# Altium schematic sheet lv_power_12V_cv.SchDoc(lv_power_12V_cv)
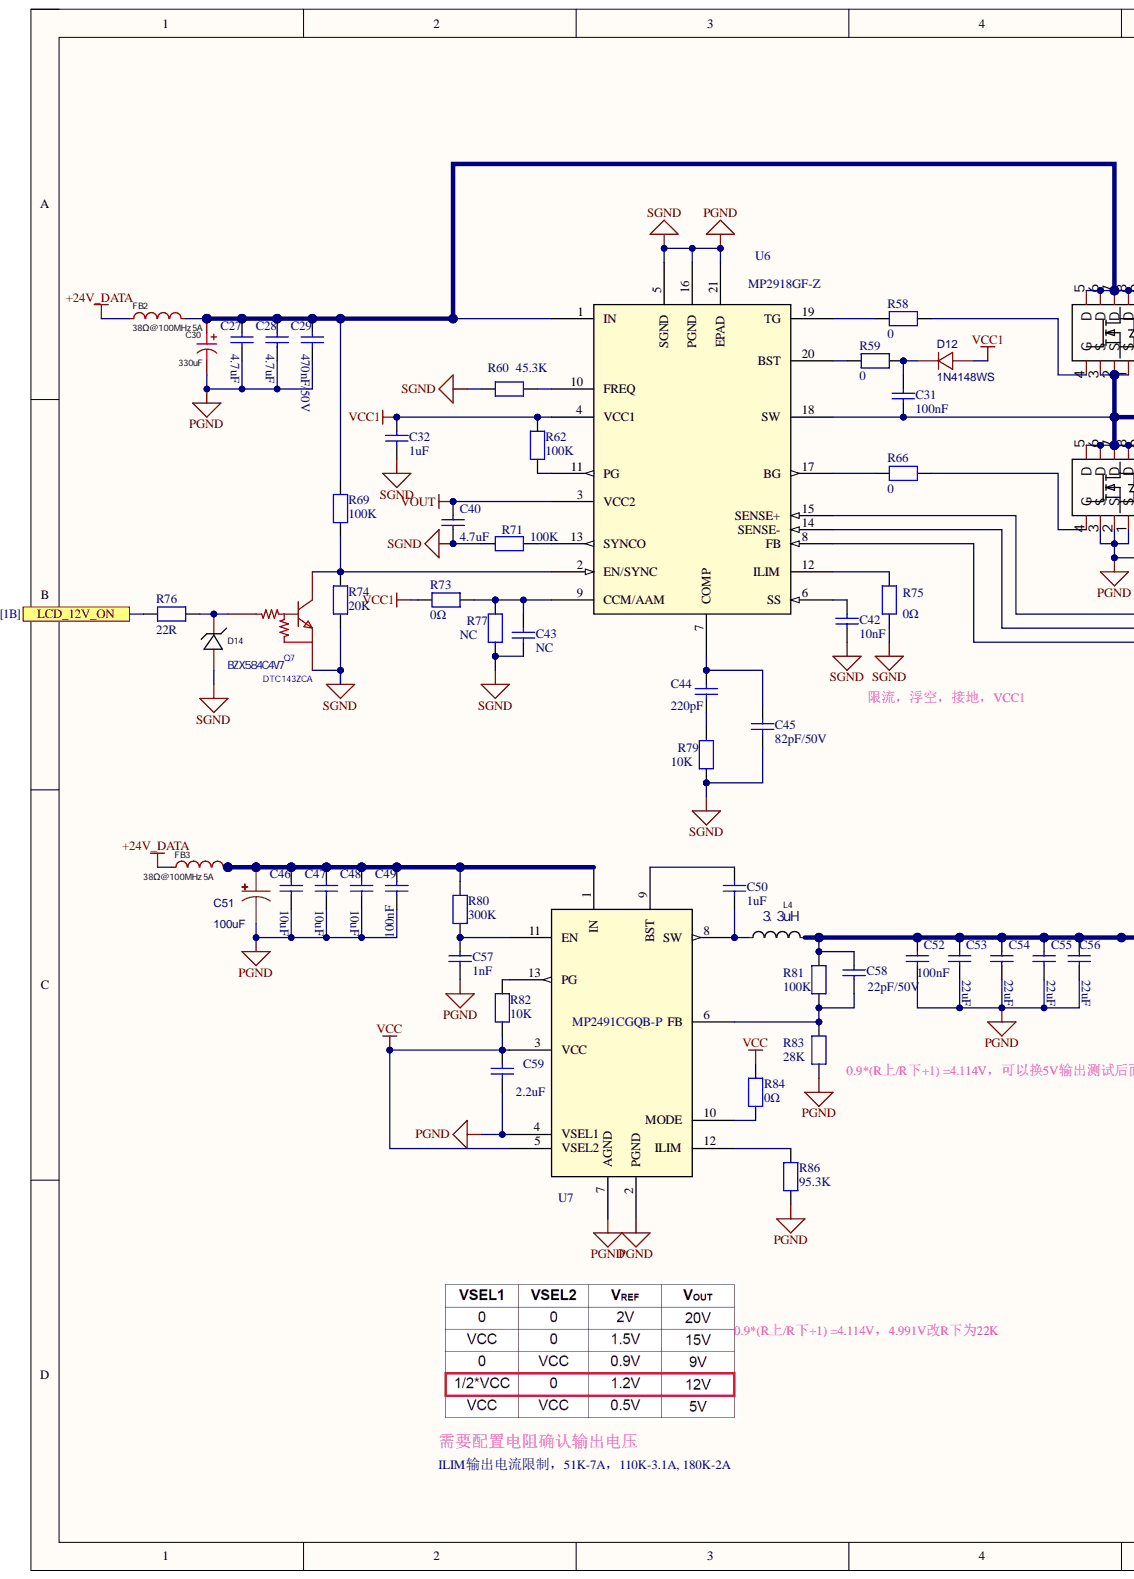
[diagram p0: lv_power_12V_cv.SchDoc, left]
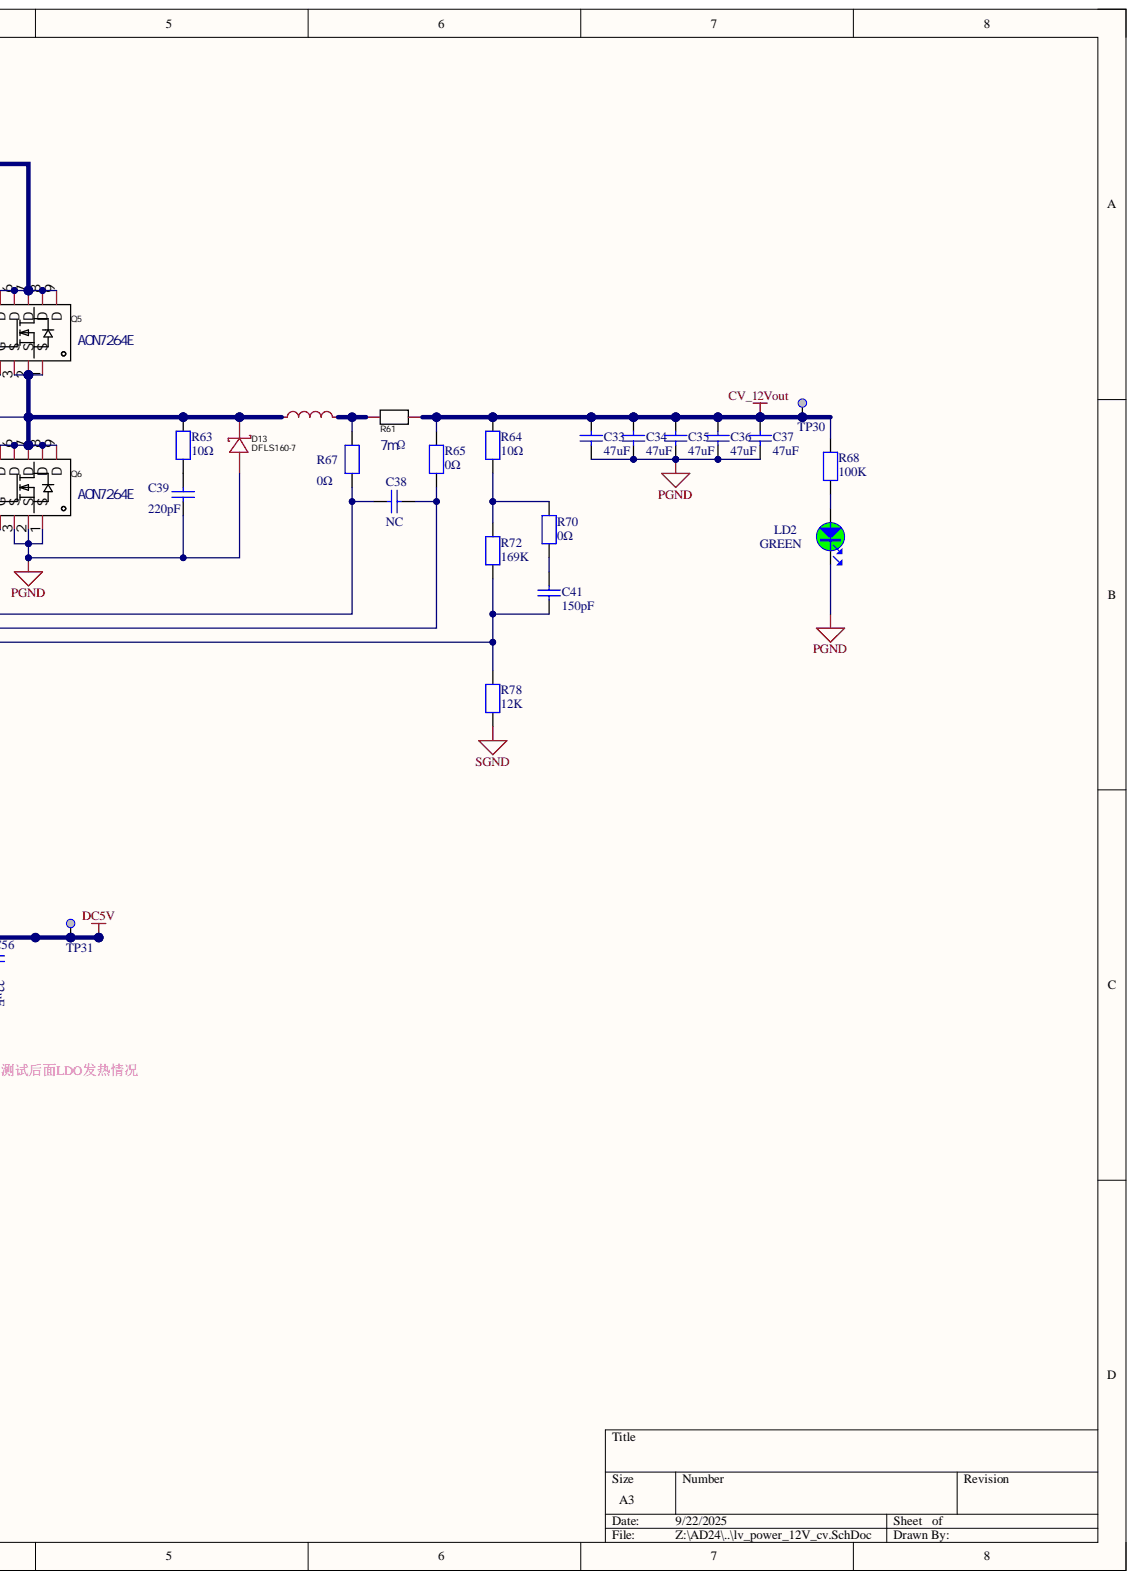
[diagram p1: lv_power_12V_cv.SchDoc, right]

## Parts
C27  4.7uF  [C1206]
C28  4.7uF  [C1206]
C29  470nF/50V  [C0603]
C30  330uF  [CAP-SMD_BD10.0-L10.3-W10.3-LS10.9-FD]
C31  100nF  [C0402]
C32  1uF  [C0402]
C33  47uF  [1206]
C34  47uF  [1206]
C35  47uF  [1206]
C36  47uF  [1206]
C37  47uF  [1206]
C38  NC  [C0402]
C39  220pF  [C0402]
C40  4.7uF  [C0603]
C41  150pF  [C0402]
C42  10nF  [C0402]
C43  NC  [C0402]
C44  220pF  [C0402]
C45  82pF/50V  [C0402]
C46  10uF  [C1206]
C47  10uF  [C1206]
C48  10uF  [C1206]
C49  100nF  [C0402]
C50  1uF  [C0805]
C51  100uF  [CAP-SMD_BD6.3-L6.6-W6.6-LS7.6-FD]
C52  100nF  [C0402]
C53  22uF  [C0805]
C54  22uF  [C0805]
C55  22uF  [C0805]
C56  22uF  [C0805]
C57  1nF  [C0402]
C58  22pF/50V  [C0402]
C59  2.2uF  [C0603]
D12  1N4148WS  [SOD-123_L2.8-W1.8-LS3.7-RD]
D13  DFLS160-7  [POWERDI-123_L2.8-W1.8-LS3.7-RD]
D14  BZX584C4V7  [SOD-523F_L1.2-W0.8-LS1.7-RD]
FB2  PZ3216D380-5R0TF  [L1206]  (value not drawn)
FB3  LCSC Part Name: 38Ω@100MHz 5A  [L1206]  (hidden comment: PZ3216D380-5R0TF)
L3  15uH  [IND-SMD_L11.9-W11.0]  (value not drawn)
L4  3.3uH  [IND-SMD_L7.2-W6.6_GPSR07X0]
LD2  value GREEN  [LED_0603_GREEN]  (hidden comment: LED_green)
Q5  AON7264E  [DFN-8_L3.0-W3.0-P0.65-BL_VBQFXXXX]
Q6  AON7264E  [DFN-8_L3.0-W3.0-P0.65-BL_VBQFXXXX]
Q7  DTC143ZCA  [SOT-416_L1.6-W0.8-P1.00-LS1.6-BR]
R58  0  [R0603]
R59  0  [R0603]
R60  45.3K  [R0402]
R61  7mΩ  [R2512]
R62  100K  [R0402]
R63  10Ω  [R0603]
R64  10Ω  [R0603]
R65  0Ω  [R0402]
R66  0  [R0603]
R67  0Ω  [R0402]
R68  100K  [R0603]
R69  100K  [R0603]
R70  0Ω  [R0603]
R71  100K  [R0402]
R72  169K  [R0603]
R73  0Ω  [R0402]
R74  20K  [R0603]
R75  0Ω  [R0402]
R76  22R  [R0402]
R77  NC  [R0402]
R78  12K  [R0603]
R79  10K  [R0402]
R80  300K  [R0603]
R81  100K  [R0402]
R82  10K  [R0402]
R83  28K  [R0402]
R84  0Ω  [R0402]
R86  95.3K  [R0402]
TP30  Test Point  [TP_SMD_1MM]  (value not drawn)  (no BOM)
TP31  Test Point  [TP_SMD_1MM]  (value not drawn)  (no BOM)
U6  MP2918GF-Z  [TSSOP-20EP_MP2918_MNP]
U7  MP2491CGQB-P  [QFN-13_2p5MMx3MM_MNP]

## Ports
LCD_12V_ON

## Nets
+24V_DATA: FB2.1 FB3.1
CV_12Vout: C33.2 C34.2 C35.2 C36.2 C37.2 R61.2 R64.2 R65.2 R68.2 TP30.1
DC5V: C52.1 C53.2 C54.2 C55.2 C56.2 C58.2 L4.2 R81.1 TP31.1
PGND: C27.1 C28.1 C29.1 C30.2 C32.2 C33.1 C34.1 C35.1 C36.1 C37.1 C39.2 C40.1 C42.2 C43.2 C45.2 C46.1 C47.1 C48.1 C49.2 C51.2 C52.2 C53.1 C54.1 C55.1 C56.1 C57.1 C59.1 D13.2 D14.2 LD2.2 Q6.1(S) Q6.2(S) Q6.3(S) Q7.2 R60.1 R71.2 R74.2 R75.2 R77.2 R78.1 R79.2 R83.2 R86.2 U6.5(SGND) U6.16(PGND) U6.21(EPAD) U7.2(PGND) U7.4(VSEL1) U7.7(AGND)
VCC: C59.2 R82.1 R84.2 U7.3(VCC) U7.5(VSEL2)
VCC1: C32.1 D12.2 R62.2 R73.1 U6.4(VCC1)
VOUT: C40.2 U6.3(VCC2)
LCD_12V_ON: R76.1 ; port LCD_12V_ON
NetC27_2: C27.2 C28.2 C29.2 C30.1 FB2.2 Q5.5(D) Q5.6(D) Q5.7(D) Q5.8(D) Q5.9(D) R69.1 U6.1(IN)
NetC31_1: C31.1 D12.1 R59.2
NetC31_2: C31.2 D13.1 L3.1 Q5.1(S) Q5.2(S) Q5.3(S) Q6.5(D) Q6.6(D) Q6.7(D) Q6.8(D) Q6.9(D) R63.2 U6.18(SW)
NetC38_1: C38.1 R67.1 U6.15(SENSE+)
NetC38_2: C38.2 R65.1 U6.14(SENSE-)
NetC39_1: C39.1 R63.1
NetC41_1: C41.1 R72.1 R78.2 U6.8(FB)
NetC41_2: C41.2 R70.1
NetC42_1: C42.1 U6.6(SS)
NetC43_1: C43.1 R73.2 R77.1 U6.9(CCM/AAM)
NetC44_1: C44.1 C45.1 U6.7(COMP)
NetC44_2: C44.2 R79.1
NetC46_2: C46.2 C47.2 C48.2 C49.1 C51.1 FB3.2 R80.2 U7.1(IN)
NetC50_1: C50.1 U7.9(BST)
NetC50_2: C50.2 L4.1 U7.8(SW)
NetC57_2: C57.2 R80.1 U7.11(EN)
NetC58_1: C58.1 R81.2 R83.1 U7.6(FB)
NetD14_1: D14.1 Q7.1 R76.2
NetL3_2: L3.2 R61.1 R67.2
NetLD2_1: LD2.1 R68.1
NetQ5_4: Q5.4(G) R58.2
NetQ6_4: Q6.4(G) R66.2
NetQ7_3: Q7.3 R69.2 R74.1 U6.2(EN/SYNC)
NetR58_1: R58.1 U6.19(TG)
NetR59_1: R59.1 U6.20(BST)
NetR60_2: R60.2 U6.10(FREQ)
NetR62_1: R62.1 U6.11(PG)
NetR64_1: R64.1 R70.2 R72.2
NetR66_1: R66.1 U6.17(BG)
NetR71_1: R71.1 U6.13(SYNCO)
NetR75_1: R75.1 U6.12(ILIM)
NetR82_2: R82.2 U7.13(PG)
NetR84_1: R84.1 U7.10(MODE)
NetR86_1: R86.1 U7.12(ILIM)

## Library data of the parts
C27 C28 = Capacitor_2: 50V, X7R, 10%; Internal_Part_Number: MCD-00014; Manufacturer: muRata; Manufacturer_Part_Number: GRM31CR71H475KA12L; Component Kind: Standard; ComponentLink1Description: Datasheet; ComponentLink1URL: http://tunmcdsvn.tun.st.com/Component_Datasheet_Library/Trunk/Capacitors/Yageo_Multilayer_capacitor_X5R_series_100pF_to_100uF; Library Name: HiPin_Library_AD.IntLib; Value: 4.7uF
C29 = Capacitor_2: 50V, X7R, 10%; Manufacturer: YAGEO; Manufacturer_Part_Number: CC0603KRX7R9BB474; Component Kind: Standard; ComponentLink1Description: Datasheet; ComponentLink1URL: http://tunmcdsvn.tun.st.com/Component_Datasheet_Library/Trunk/Capacitors/Yageo_Multilayer_capacitor_X5R_series_100pF_to_100uF; Library Name: HiPin_Library_AD.IntLib
C30 = HV1V337M1010PZ: 330uF, 35V, 20%; Manufacturer: HONOR(荣誉); Add into BOM: yes; L: 10mm; Component Kind: Standard; Convert to PCB: yes; Datasheet: https://atta.szlcsc.com/upload/public/pdf/source/20240802/61683F5000C7CFED5606ABBE3CFBE0F5.pdf; Device: HV1V337M1010PZ; JLCPCB Part Class: Extended Part; LCSC Part Name: 330uF ±20% 35V; Library Name: HiPin_Library_AD.IntLib; Manufacturer Part: HV1V337M1010PZ; Operating Temperature: -55℃~+105℃; Rated Voltage: 35V; Supplier: LCSC; Supplier Footprint: SMD,D10xL10mm; Supplier Part: C5246558; Symbol: HV1V337M1010PZ; Tolerance: ±20%; Value: 330uF; φD: 10mm
C31 = Capacitor: 50V, 10%, X7R; Manufacturer_Part_Number: GRM155R61E105KA12D; Component Kind: Standard; ComponentLink1Description: Datasheet; ComponentLink1URL: http://tunmcdsvn.tun.st.com/Component_Datasheet_Library/Trunk/Capacitors/Yageo_Capacitor_NP0_16V-to-50V; Library Name: HiPin_Library_AD.IntLib; Package: C0402; Value: 100nF
C32 = Capacitor: 25V, 10%, X5R; Manufacturer_Part_Number: GRM155R61E105KA12D; Component Kind: Standard; ComponentLink1Description: Datasheet; ComponentLink1URL: http://tunmcdsvn.tun.st.com/Component_Datasheet_Library/Trunk/Capacitors/Yageo_Capacitor_NP0_16V-to-50V; Library Name: HiPin_Library_AD.IntLib; Package: C0402; Value: 1uF
C33-C37 = Capacitor_3: 25V, X5R, 20%; Internal_Part_Number: MCD-00008; Manufacturer: muRata; Manufacturer_Part_Number: GRM31CR61E476ME44L; Component Kind: Standard; Library Name: HiPin_Library_AD.IntLib; Value: 47uF
C38 C39 C44 = Capacitor: 50V, 5%,C0G; Manufacturer_Part_Number: GCM1885C1H221JA16D; Component Kind: Standard; ComponentLink1Description: Datasheet; ComponentLink1URL: http://tunmcdsvn.tun.st.com/Component_Datasheet_Library/Trunk/Capacitors/Yageo_Capacitor_NP0_16V-to-50V; Library Name: HiPin_Library_AD.IntLib; Package: C0402
C40 = Capacitor_1: 16V, X7S, 10%; Internal_Part_Number: MCD-00009; Manufacturer: muRata; Manufacturer_Part_Number: GRM188C71C475KE21D; Component Kind: Standard; ComponentLink1Description: Yageo_Capacitor_X5R-series.pdf; ComponentLink1URL: http://tunmcdsvn.tun.st.com/Component_Datasheet_Library/Trunk/Capacitors/Yageo_Multilayer_capacitor_X5R_series_100pF_to_100uF; Library Name: HiPin_Library_AD.IntLib; Package: C0603; Value: 4.7uF
C41 = Capacitor: 50V, 5%,C0G; Manufacturer_Part_Number: GRM1555C1H151JA01D; Component Kind: Standard; ComponentLink1Description: Datasheet; ComponentLink1URL: http://tunmcdsvn.tun.st.com/Component_Datasheet_Library/Trunk/Capacitors/Yageo_Capacitor_NP0_16V-to-50V; Library Name: HiPin_Library_AD.IntLib; Package: C0402
C42 = Capacitor: 25V, 5%, C0G; Manufacturer_Part_Number: GRM1555C1E103JE01D; Component Kind: Standard; ComponentLink1Description: Datasheet; ComponentLink1URL: http://tunmcdsvn.tun.st.com/Component_Datasheet_Library/Trunk/Capacitors/Yageo_Capacitor_NP0_16V-to-50V; Library Name: HiPin_Library_AD.IntLib; Package: C0402
C43 = Capacitor: 25V, 10%, X5R; Manufacturer_Part_Number: GRM155R61E105KA12D; Value: 1uF; Component Kind: Standard; ComponentLink1Description: Datasheet; ComponentLink1URL: http://tunmcdsvn.tun.st.com/Component_Datasheet_Library/Trunk/Capacitors/Yageo_Capacitor_NP0_16V-to-50V; Library Name: HiPin_Library_AD.IntLib; Package: C0402
C45 = Capacitor: 50V, 1%, NP0; Manufacturer: YAGEO; Manufacturer_Part_Number: CC0402FRNPO9BN820; Component Kind: Standard; Library Name: HiPin_Library_AD.IntLib; Package: C0402
C46-C48 = Capacitor_2: 35V, X5R, 10%; Internal_Part_Number: MCD-00014; Manufacturer: muRata; Manufacturer_Part_Number: GRM31CR61H106KA12L; Component Kind: Standard; ComponentLink1Description: Datasheet; ComponentLink1URL: http://tunmcdsvn.tun.st.com/Component_Datasheet_Library/Trunk/Capacitors/Yageo_Multilayer_capacitor_X5R_series_100pF_to_100uF; Library Name: HiPin_Library_AD.IntLib; Value: 10uF
C49 C52 = Capacitor: 50V, 10%, X7R; Internal_Part_Number: MCD-00003; Manufacturer: muRata; Manufacturer_Part_Number: GRM155R71H104KE14D; Component Kind: Standard; ComponentLink1Description: Datasheet; ComponentLink1URL: http://tunmcdsvn.tun.st.com/Component_Datasheet_Library/Trunk/Capacitors/Yageo_Capacitor_NP0_16V-to-50V; Library Name: HiPin_Library_AD.IntLib; Package: C0402; Value: 100nF
C50 = Capacitor: 50V, 10%, X7R; Internal_Part_Number: MCD-00003; Manufacturer_Part_Number: GRM21BR71H105KA12L; Component Kind: Standard; ComponentLink1Description: Datasheet; ComponentLink1URL: http://tunmcdsvn.tun.st.com/Component_Datasheet_Library/Trunk/Capacitors/Yageo_Capacitor_NP0_16V-to-50V; Library Name: HiPin_Library_AD.IntLib; Package: C0805; Value: 1uF
C51 = 100uF: 50V, 20%, 229mA@120Hz; Manufacturer: Nichicon; Manufacturer_Part_Number: RVT1H101M0607; Component Kind: Standard; Library Name: HiPin_Library_AD.IntLib
C53-C56 = Capacitor_2: 25V, X5R, 10%; Internal_Part_Number: MCD-00014; Manufacturer: muRata; Manufacturer_Part_Number: GRM21BR61E226ME44L; Component Kind: Standard; ComponentLink1Description: Datasheet; ComponentLink1URL: http://tunmcdsvn.tun.st.com/Component_Datasheet_Library/Trunk/Capacitors/Yageo_Multilayer_capacitor_X5R_series_100pF_to_100uF; Library Name: HiPin_Library_AD.IntLib; Value: 22uF
C57 = Capacitor: 50V, 10%, X7R; Internal_Part_Number: MCD-00003; Manufacturer: muRata; Component Kind: Standard; ComponentLink1Description: Datasheet; ComponentLink1URL: http://tunmcdsvn.tun.st.com/Component_Datasheet_Library/Trunk/Capacitors/Yageo_Capacitor_NP0_16V-to-50V; Library Name: HiPin_Library_AD.IntLib; Package: C0402; Value: 1nF
C58 = Capacitor: 50V, 10%, NP0; Manufacturer: muRata; Manufacturer_Part_Number: CC0402FRNPO9BN220; Component Kind: Standard; Library Name: HiPin_Library_AD.IntLib
C59 = Capacitor_1: 25V, X5R, 10%; Internal_Part_Number: MCD-00009; Manufacturer: muRata; Manufacturer_Part_Number: GRM155R61E225KE11D; Component Kind: Standard; ComponentLink1Description: Yageo_Capacitor_X5R-series.pdf; ComponentLink1URL: http://tunmcdsvn.tun.st.com/Component_Datasheet_Library/Trunk/Capacitors/Yageo_Multilayer_capacitor_X5R_series_100pF_to_100uF; Library Name: HiPin_Library_AD.IntLib; Package: C0603; Value: 2.2uF
D12 = 1N4148W-C84367: BOM_Manufacturer: MDD; BOM_JLCPCB Part Class: Extended Part; BOM_Manufacturer Part: 1N4148W; BOM_Supplier: LCSC; BOM_Supplier Part: C84367; Component Kind: Standard; Library Name: HiPin_Library_AD.IntLib; spicePre: D; spiceSymbolName: 1N4148W-C84367
D13 = DFLS160-7: Manufacturer: DIODES(美台); Add into BOM: yes; Forward Voltage (Vf@If): 500mV@1A; Rectified Current: 1A; Reverse Voltage (Vr): 60V; Component Kind: Standard; Convert to PCB: yes; Datasheet: https://atta.szlcsc.com/upload/public/pdf/source/20181114/C177023_80F0A2460033305602CFDDCB3101FB4E.pdf; Device: DFLS160-7; JLCPCB Part Class: Extended Part; LCSC Part Name: 电压:60V 电流:1A; Library Name: HiPin_Library_AD.IntLib; Manufacturer Part: DFLS160-7; PCB3D: POWERDI-123_L2.8-W1.8-H1.0-LS3.7; Supplier: LCSC; Supplier Footprint: Power-DI-123; Supplier Part: C177023; Symbol: DFLS160-7
D14 = LM5Z3V6T1G: 4.8~5.4V, 200mW; Power Dissipation: 500mW; Component Kind: Standard; Library Name: HiPin_Library_AD.IntLib; Manufacturer Part: BZX584C4V7; PCB3D: SOD-123_L2.7-W1.6-H1.4-LS3.7; Supplier Footprint: SOD-123; Tolerance: -
FB2 FB3 = BLM31PG601SN1L: Manufacturer: Sunlord(顺络); Add into BOM: yes; Circuits: 1; Current Rating: 1.5A; DC Resistance: 80mΩ; Impedance @ Frequency: 600Ω@100MHz; Tolerance: ±25%; Component Kind: Standard; Convert to PCB: yes; Datasheet: https://atta.szlcsc.com/upload/public/pdf/source/20180326/C88997_FE7208E239BA92CF7577D85CF2703D30.pdf; Device: BLM31PG601SN1L; JLCPCB Part Class: Extended Part; Library Name: HiPin_Library_AD.IntLib; Manufacturer Part: PZ3216D380-5R0TF; Supplier: LCSC; Supplier Footprint: 1206; Supplier Part: C16902; Symbol: BLM31PG601SN1L
  FB2: LCSC Part Name: 38Ω@100MHz 5A
L3 = FEXL1010A-150M: 电感值: 15uH  精度: ±20%  额定电流: 13.8A  饱和电流(Isat): 15.5A; Manufacturer: cjiang(长江微电); Add into BOM: yes; DC Resistance (DCR): 19.3mΩ; Type: 一体成型电感; Component Kind: Standard; Convert to PCB: yes; Datasheet: https://atta.szlcsc.com/upload/public/pdf/source/20240409/B9FA7ECCC3220F3F7C7BE8F7B1FCE238.pdf; Device: FEXL1010A-150M; JLCPCB Part Class: Extended Part; LCSC Part Name: 15uH ±20% 15.5A; Library Name: HiPin_Library_AD.IntLib; Manufacturer Part: FEXL1010A-150M; PCB3D: IND-SMD_L11.9-W11.0; Rated Current: 13.8A; Ratings: AEC-Q200; Saturation Current (Isat): 15.5A; Supplier: LCSC; Supplier Footprint: SMD,11.9x11mm; Supplier Part: C5378579; Symbol: FEXL1010A-150M; Tolerance: ±20%; Value: 15uH
L4 = CYA0630-10UH: 22.9mΩ，20%, 8A; Manufacturer: PROD; Manufacturer Part: PSPMAA0605H-3R3M-ANP; DC Resistance (DCR): 29mΩ; Tolerance: ±20%; Value: 4.7uH; Component Kind: Standard; Library Name: HiPin_Library_AD.IntLib; PCB3D: IND-SMD_L7.2-W6.6-H3.0_GPSR07X0; Supplier Footprint: SMD,6.6x7.2mm
LD2 = LED_green: LED, Green, 0603; Internal_Part_Number: MCD-00050; Manufacturer: EVERLIGHT; Manufacturer_Part_Number: 19-213SYGC/S530-E2/TR8; Part_Type: Display; ECCN_EU: NEC; ECCN_US: EAR99; Provided_By: SUBCO; Component Kind: Standard; ComponentLink1Description: Datasheet; ComponentLink1URL: http://tunmcdsvn.tun.st.com/Component_Datasheet_Library/Trunk/Display/Everlight_SMD-LED-GREEN_19-213SYGC-S530-XX-TR8-v3.doc; Library Name: mb1367.IntLib; Package: LED 0603; Status: Released
Q5 Q6 = HYG045N03LA1C1: NMOS; Manufacturer: HUAYI(����΢); Add into BOM: yes; Continuous Drain Current (Id): 50A; Drain Source On Resistance (RDS(on)@Vgs,Id): 3.9m��@10V,20A; Drain Source Voltage (Vdss): 30V; Gate Threshold Voltage (Vgs(th)@Id): 1.6V@250uA; Input Capacitance (Ciss@Vds): 2.106nF@25V; Power Dissipation (Pd): 23W; Reverse Transfer Capacitance (Crss@Vds): 228pF@25V; Total Gate Charge (Qg@Vgs): 46.2nC@10V; Type: N����; Component Kind: Standard; Convert to PCB: yes; Datasheet: https://atta.szlcsc.com/upload/public/pdf/source/20211028/5F8459D5B1D3B8F85ED46FBAED5B3F3F.pdf; Device: HYG045N03LA1C1; JLCPCB Part Class: Extended Part; LCSC Part Name: N���� ��ѹ:30V ����:50A; Library Name: HiPin_Library_AD.IntLib; Manufacturer Part: HYG045N03LA1C1; Operating Temperature: -55��~+175��@(Tj); Supplier: LCSC; Supplier Footprint: DFN-8(3x3); Supplier Part: C2911691; Symbol: HYG045N03LA1C1
Q7 = DTC043ZEBTL: NPN 三极管; Manufacturer: ROHM(罗姆); Add into BOM: yes; Collector Current (Ic): 100mA; Collector-Emitter Breakdown Voltage (Vceo): 50V; DC Current Gain (hFE@Ic,Vce): 80@5mA,10V; Input Resistor: 4.7kΩ; Input Voltage (VI(on)@Ic,Vce): 1.1V@5mA,0.3V; Output Voltage (VO(on)@Io/Ii): 150mV@5mA,0.5mA; Power Dissipation (Pd): 150mW; Resistor Ratio: 10; Component Kind: Standard; Convert to PCB: yes; Datasheet: https://atta.szlcsc.com/upload/public/pdf/source/20230825/AFF9D3CF4DD067520C5D7C04408FCBF2.pdf; Device: DTC043ZEBTL; JLCPCB Part Class: Extended Part; LCSC Part Name: 耐压:50V 电流:100mA; Library Name: HiPin_Library_AD.IntLib; Manufacturer Part: DTC043ZEBTL; Operating Temperature: -55℃~+150℃; PCB3D: SOT-416-3P_L1.6-W0.8-H0.9-LS1.6-P1.00; Supplier: LCSC; Supplier Footprint: SOT-416FL; Supplier Part: C96459; Symbol: DTC043ZEBTL; Transistor Type: -
R58-R60 R62-R78 R80 R81 R83 R84 R86 = RES: 1%; Value: 10K; Component Kind: Standard; Library Name: HiPin_Library_AD.IntLib
R61 = PA2512FKE070R005E: R2512-2W-7mR-1%-15ppm; Manufacturer: Milliohm(毫欧); Component Kind: Standard; Library Name: HiPin_Library_AD.IntLib; Manufacturer Part: R2512-2W-7mR-1%-15ppm; PCB3D: RES-SMD_L6.4-W3.2_C500733
R79 R82 = RES: 1%; Component Kind: Standard; Library Name: HiPin_Library_AD.IntLib; Value: 10K
TP30 TP31 = MCD-00074: Test Point 1mm; Part_Type: Mechanical; Database Table Name: MCD_PARTS$; ComponentLink1Description: Datasheet; ComponentLink1URL: http://na; ECCN_EU: n/a; ECCN_US: n/a; Internal_Part_Number: MCD-00074; Library Name: MCD_PARTS_database.DbLib; Manufacturer: n/a; Manufacturer_Part_Number: n/a; Provided_By: n/a; Status: Released
U6 = MP2918GF-Z: No Description Available; Mfr_Name: Monolithic Power Systems; Component Kind: Standard; Library Name: HiPin_Library_AD.IntLib; RefDes: RefDes; Type: Type
U7 = MP2491CGQB-P: No Description Available; Mfr_Name: Monolithic Power Systems; Component Kind: Standard; Library Name: HiPin_Library_AD.IntLib; RefDes: RefDes; Type: Type
